# Altium schematic sheet output&ext-modules.SchDoc
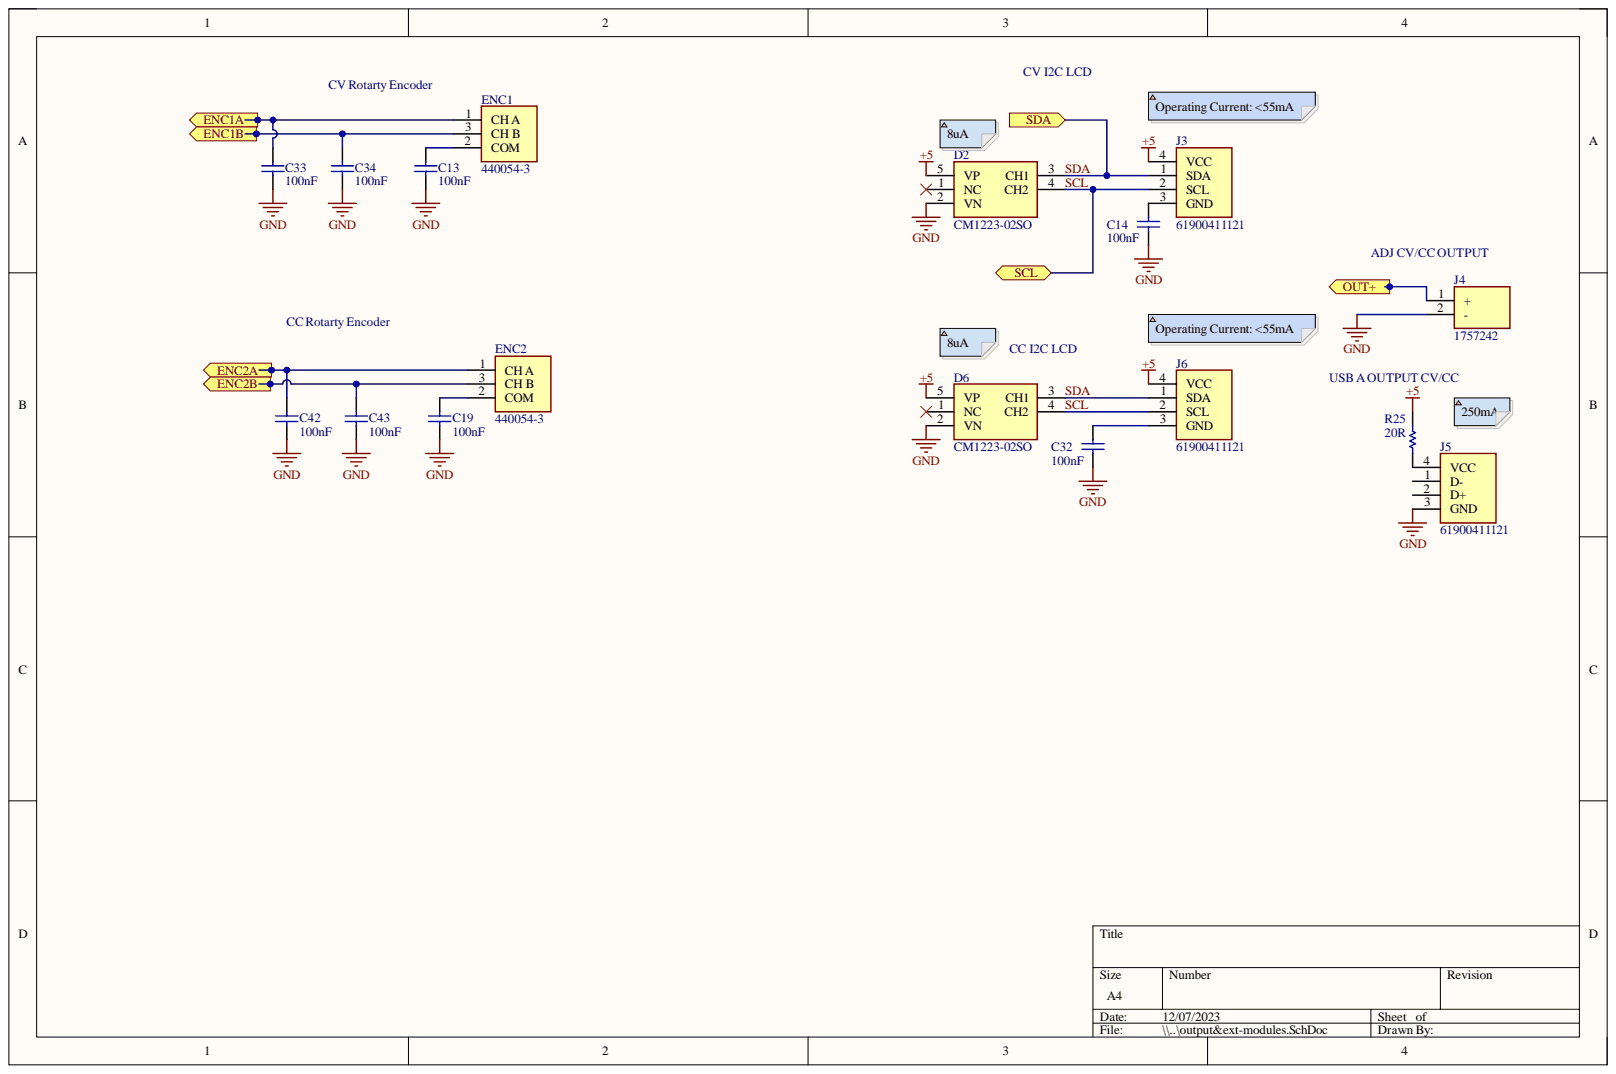
[diagram p0: output&ext-modules.SchDoc]

## Parts
C13  value 100nF  [Cap_0603]  (hidden comment: Cap)
C14  value 100nF  [Cap_0603]  (hidden comment: Cap)
C19  value 100nF  [Cap_0603]  (hidden comment: Cap)
C32  value 100nF  [Cap_0603]  (hidden comment: Cap)
C33  value 100nF  [Cap_0603]  (hidden comment: Cap)
C34  value 100nF  [Cap_0603]  (hidden comment: Cap)
C42  value 100nF  [Cap_0603]  (hidden comment: Cap)
C43  value 100nF  [Cap_0603]  (hidden comment: Cap)
D2  CM1223-02SO  [SOT95P280X145-5N]
D6  CM1223-02SO  [SOT95P280X145-5N]
ENC1  440054-3  [SHDR3W50P0X200_1X3_800X470X635P]
ENC2  440054-3  [SHDR3W50P0X200_1X3_800X470X635P]
J3  61900411121  [SHDR4W64P0X254_1X4_1020X580X1175P]
J4  1757242  [1757242]
J5  61900411121  [SHDR4W64P0X254_1X4_1020X580X1175P]
J6  61900411121  [SHDR4W64P0X254_1X4_1020X580X1175P]
R25  value 20R  [RES_0805]  (hidden comment: Res1)

## Ports
ENC1A, ENC1B, ENC2A, ENC2B, OUT+, SCL, SDA

## Nets
+5: D2.5(VP) D6.5(VP) J3.4(VCC) J6.4(VCC) R25.2
GND: C13.2 C14.2 C19.2 C32.2 C33.2 C34.2 C42.2 C43.2 D2.2(VN) D6.2(VN) J4.2 J5.3(GND)
ENC1A: C33.1 ENC1.1 ; port ENC1A
ENC1B: C34.1 ENC1.3 ; port ENC1B
ENC2A: C42.1 ENC2.1 ; port ENC2A
ENC2B: C43.1 ENC2.3 ; port ENC2B
OUT+: J4.1 ; port OUT+
SCL: D2.4(CH2) D6.4(CH2) J3.2(SCL) J6.2(SCL) ; port SCL
SDA: D2.3(CH1) D6.3(CH1) J3.1(SDA) J6.1(SDA) ; port SDA
NetC13_1: C13.1 ENC1.2
NetC14_1: C14.1 J3.3(GND)
NetC19_1: C19.1 ENC2.2
NetC32_1: C32.1 J6.3(GND)
NetD2_1: D2.1(NC)
NetJ5_4: J5.4(VCC) R25.1

Not connected: D6 1(NC); J5 1(D-) 2(D+)

## Library data of the parts
C13 C14 C19 C32-C34 C42 C43 = Cap: Capacitor; Component Kind: Standard; LatestRevisionDate: 17-Jul-2002; LatestRevisionNote: Re-released for DXP Platform.; PackageDescription: Radial Cap, Thru-Hole; 2 Leads; 0.3 in Pin Spacing; PackageReference: RAD-0.3; Published: 8-Jun-2000; Signal Integrity: Cap; Simulation: CAP; Library Name: cc cv power supply.IntLib; Publisher: Altium Limited
D2 D6 = CM1223-02SO: TVS Diode (Uni-directional); Manufacturer_Name: onsemi; Component Kind: Standard; ComponentLink1Description: Datasheet; ComponentLink1URL: https://www.onsemi.com/pdf/datasheet/cm1223-d.pdf; Datasheet Link: https://www.onsemi.com/pdf/datasheet/cm1223-d.pdf; Height: 1.45mm; Library Name: SamacSys.SchLib; Manufacturer_Part_Number: CM1223-02SO; Mouser Part Number: 748-CM1223-02SO; Mouser Price/Stock: https://www.mouser.co.uk/ProductDetail/onsemi/CM1223-02SO?qs=lLuKAerq7nnvVg3rEtIojA%3D%3D
ENC1 ENC2 = 440054-3: Connector; Manufacturer_Name: TE Connectivity; Arrow Part Number: 440054-3; Arrow Price/Stock: https://www.arrow.com/en/products/440054-3/te-connectivity?region=nac; Component Kind: Standard; ComponentLink1Description: Encoder Datasheet; ComponentLink1URL: https://www.farnell.com/datasheets/3750970.pdf; Datasheet Link: https://www.te.com/commerce/DocumentDelivery/DDEController?Action=srchrtrv&DocNm=440054&DocType=Customer+Drawing&DocLang=English&DocFormat=pdf&PartCntxt=440054-3; Height: 6.35mm; Library Name: SamacSys.SchLib; Manufacturer_Part_Number: 440054-3; Mouser Part Number: 571-440054-3; Mouser Price/Stock: https://www.mouser.co.uk/ProductDetail/TE-Connectivity/440054-3?qs=JFPmFzpscO5ATC%252BmN3ka1Q%3D%3D
J3 J5 J6 = 61900411121: Connector; Manufacturer_Name: Wurth Elektronik; Component Kind: Standard; Datasheet Link: https://katalog.we-online.de/em/datasheet/6190xx11121.pdf; Height: 11.75mm; Library Name: SamacSys.SchLib; Manufacturer_Part_Number: 61900411121; Mouser Part Number: 710-61900411121; Mouser Price/Stock: https://www.mouser.co.uk/ProductDetail/Wurth-Elektronik/61900411121?qs=W%252B2sBeLta1Z79%252BA04MeSCQ%3D%3D
  J3 J6: ComponentLink1Description: LCD Datasheet; ComponentLink1URL: https://www.farnell.com/datasheets/3708797.pdf
  J5: ComponentLink1Description: USB A; ComponentLink1URL: https://www.te.com/usa-en/product-1932258-1.datasheet.pdf
J4 = 1757242: Connector; Manufacturer_Name: Phoenix Contact; Arrow Part Number: 1757242; Arrow Price/Stock: https://www.arrow.com/en/products/1757242/phoenix-contact?region=nac; Component Kind: Standard; ComponentLink1Description: Datasheet; ComponentLink1URL: http://www.phoenixcontact.com/de/produkte/1757242/pdf; Datasheet Link: http://www.phoenixcontact.com/de/produkte/1757242/pdf; Height: 8.72mm; Manufacturer_Part_Number: 1757242; Mouser Part Number: 651-1757242; Mouser Price/Stock: https://www.mouser.co.uk/ProductDetail/Phoenix-Contact/1757242?qs=uD%2FdkN7XIa3Ejiz9qDxlzw%3D%3D
R25 = Res1: Resistor; Component Kind: Standard; LatestRevisionDate: 17-Jul-2002; LatestRevisionNote: Re-released for DXP Platform.; PackageDescription: Axial Device, Thru-Hole; 2 Leads; 0.3 in Pin Spacing; PackageReference: AXIAL-0.3; Published: 8-Jun-2000; Signal Integrity: Res; Simulation: RESISTOR; Library Name: cc cv power supply.IntLib; Publisher: Altium Limited
